# Altium schematic sheet RCU_v2_Comms.SchDoc(RCU_v2_Comms)
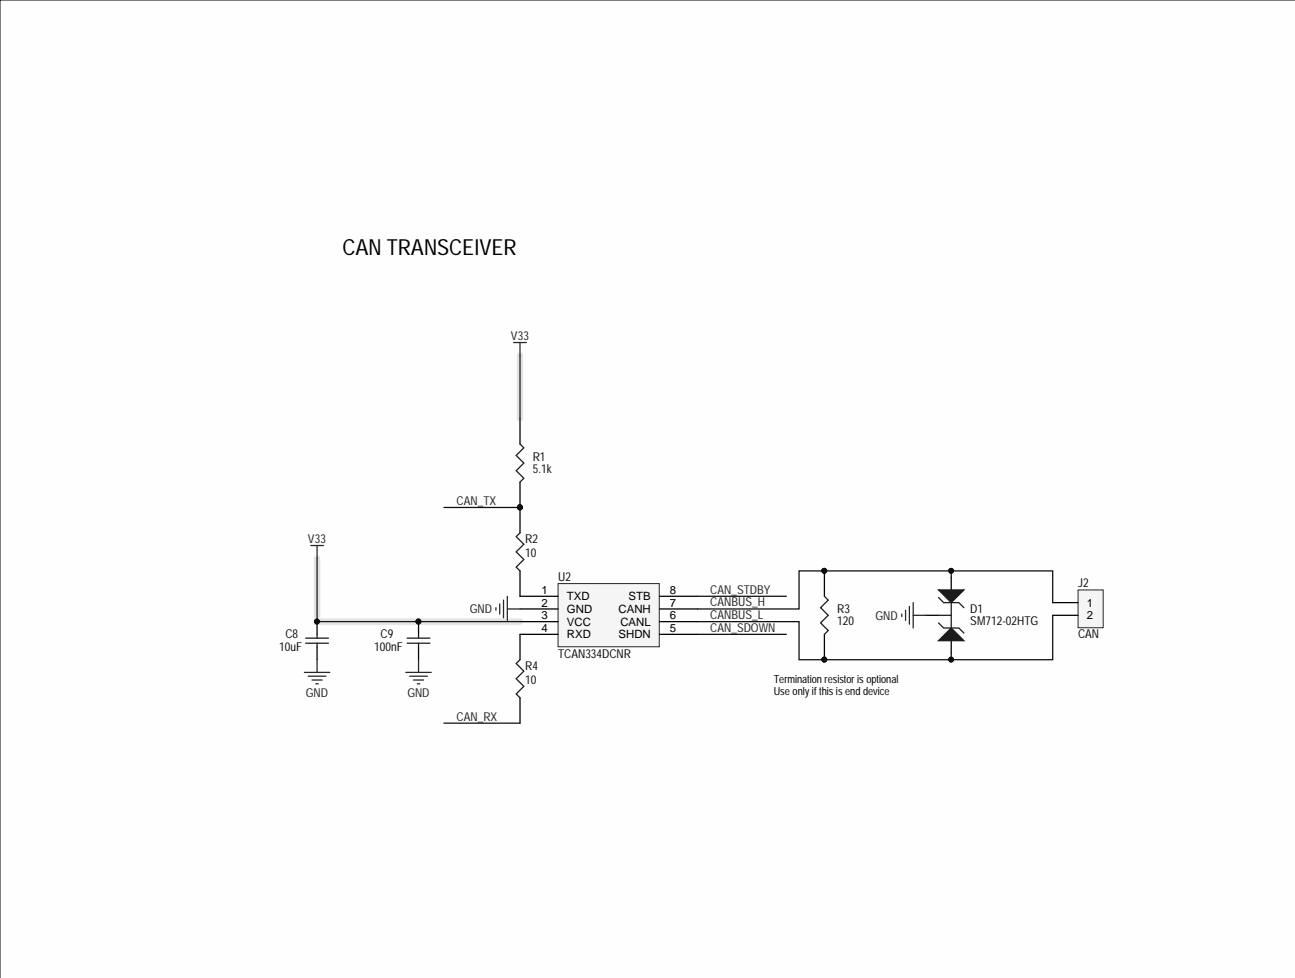
[diagram p0: RCU_v2_Comms.SchDoc, top left]
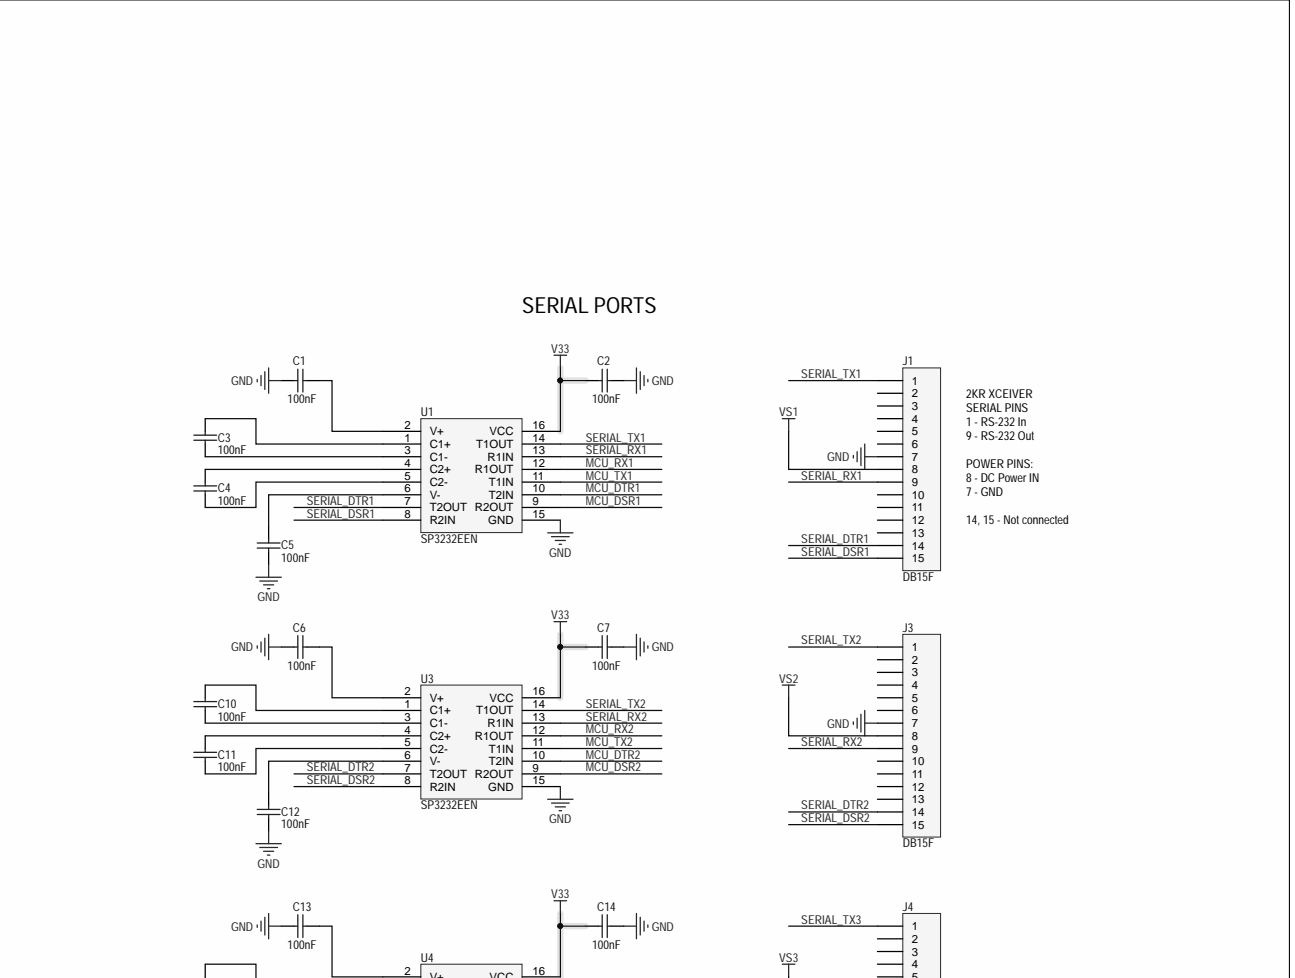
[diagram p1: RCU_v2_Comms.SchDoc, top right]
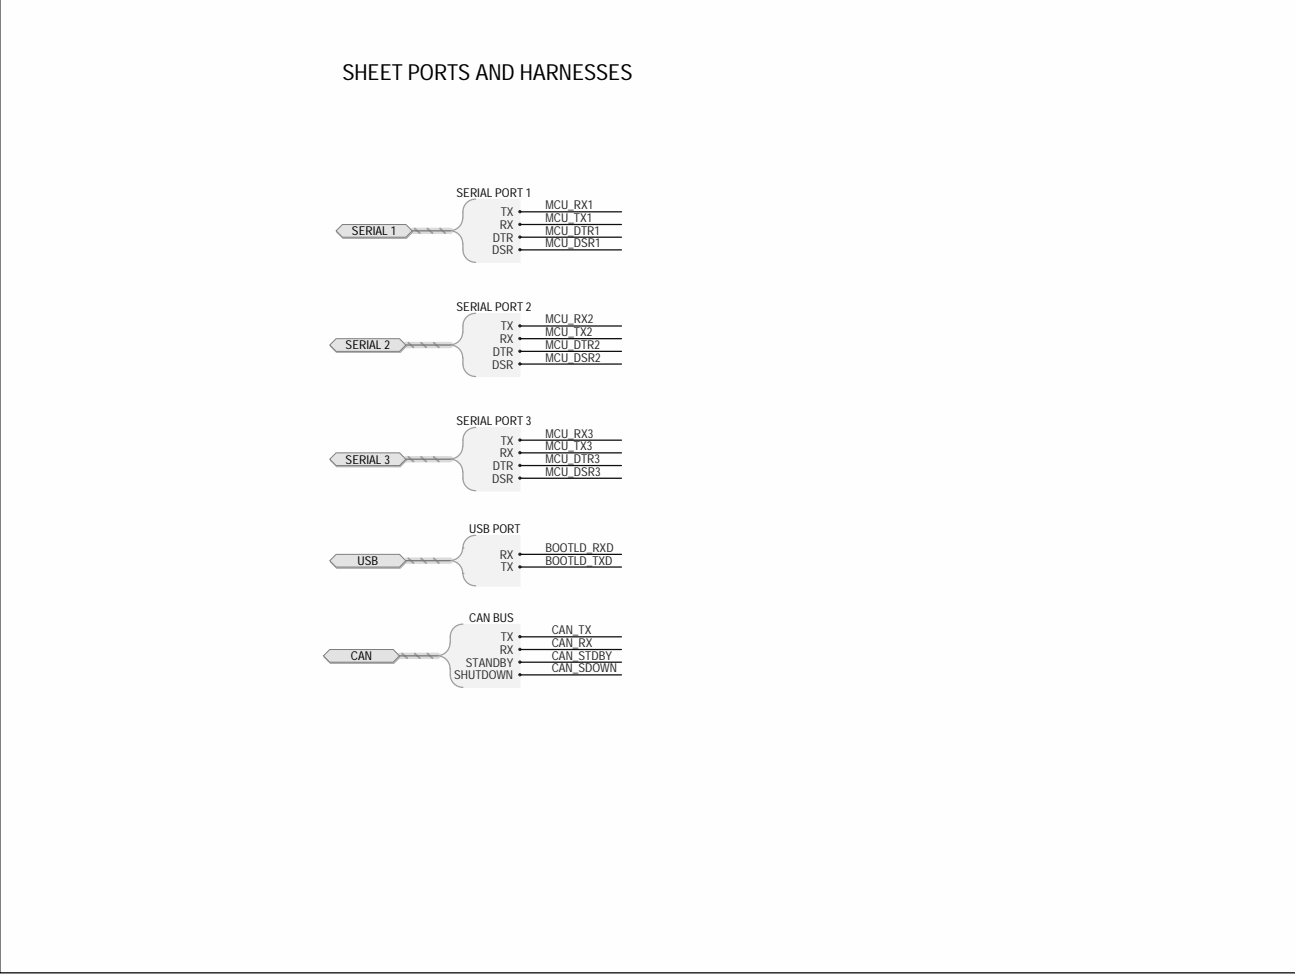
[diagram p2: RCU_v2_Comms.SchDoc, bottom left]
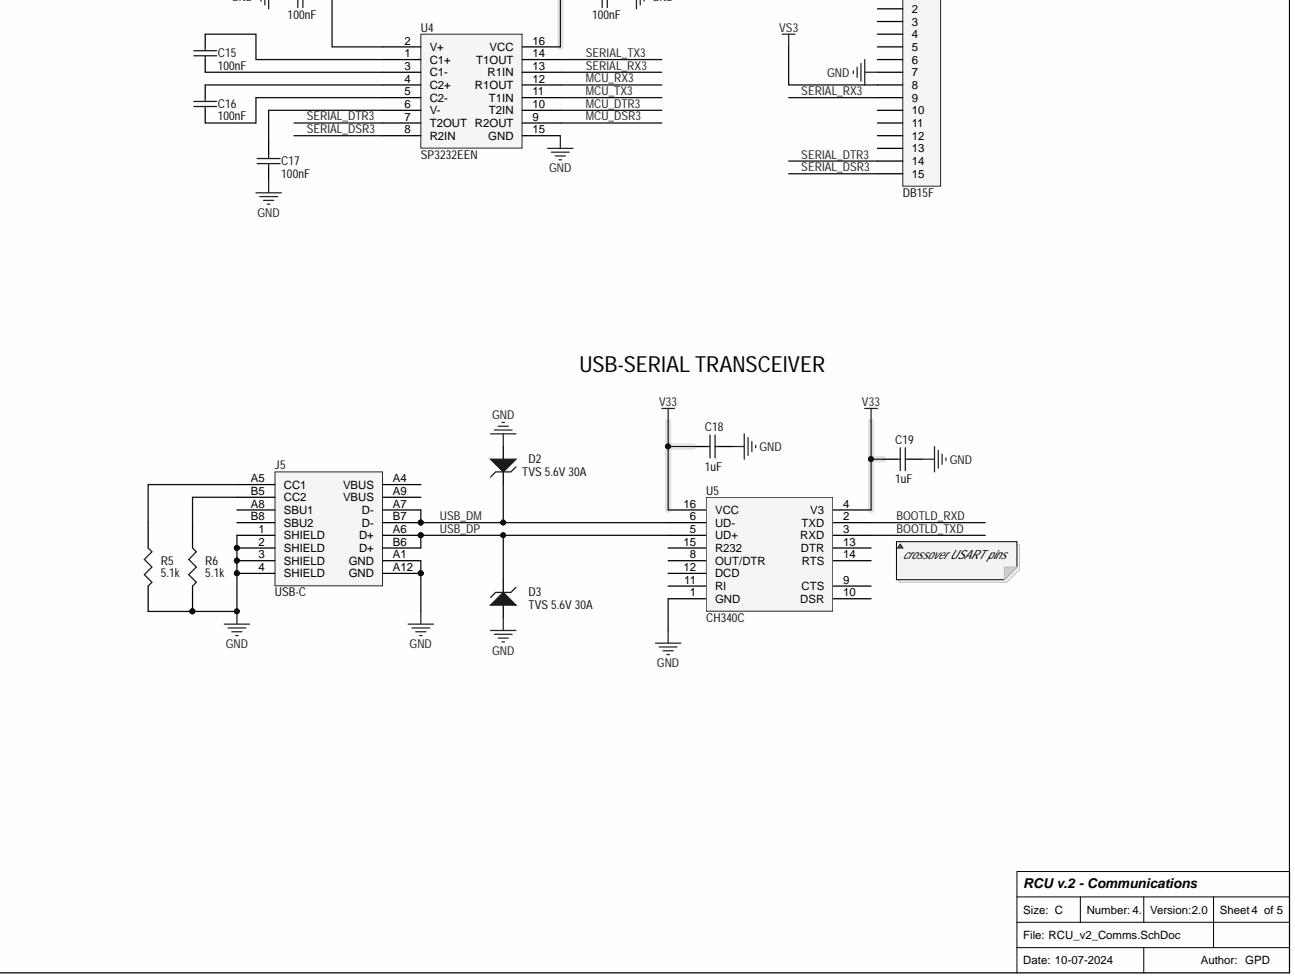
[diagram p3: RCU_v2_Comms.SchDoc, bottom right]

## Parts
C1  100nF  [C0402]
C2  100nF  [C0402]
C3  100nF  [C0402]
C4  100nF  [C0402]
C5  100nF  [C0402]
C6  100nF  [C0402]
C7  100nF  [C0402]
C8  10uF  [C1206]
C9  100nF  [C0402]
C10  100nF  [C0402]
C11  100nF  [C0402]
C12  100nF  [C0402]
C13  100nF  [C0402]
C14  100nF  [C0402]
C15  100nF  [C0402]
C16  100nF  [C0402]
C17  100nF  [C0402]
C18  1uF  [C0402]
C19  1uF  [C0402]
D1  SM712-02HTG  [SOT23_MBR4148]
D2  TVS 5.6V 30A  [SOD523]
D3  TVS 5.6V 30A  [SOD523]
J1  DB15F  [DB15FLE]
J2  CAN  [SIP2]
J3  DB15F  [DB15FLE]
J4  DB15F  [DB15FLE]
J5  USB-C  [USB_C_2]
R1  5.1k  [R0402]
R2  10  [R0402]
R3  120  [R1206]
R4  10  [R0402]
R5  5.1k  [R0402]
R6  5.1k  [R0402]
U1  SP3232EEN  [SO-G16]
U2  TCAN334DCNR  [SO-G8]
U3  SP3232EEN  [SO-G16]
U4  SP3232EEN  [SO-G16]
U5  CH340C  [SO-G16]

## Ports
CAN, CAN.RX, CAN.SHUTDOWN, CAN.STANDBY, CAN.TX, SERIAL 1, SERIAL 1.DSR, SERIAL 1.DTR, SERIAL 1.RX, SERIAL 1.TX, SERIAL 2, SERIAL 2.DSR, SERIAL 2.DTR, SERIAL 2.RX, SERIAL 2.TX, SERIAL 3, SERIAL 3.DSR, SERIAL 3.DTR, SERIAL 3.RX, SERIAL 3.TX, USB, USB.RX, USB.TX

## Nets
GND: C1.2 C2.1 C5.2 C6.2 C7.1 C8.2 C9.2 C12.2 C13.2 C14.1 C17.2 C18.2 C19.2 D1.2 D2.1 D3.1 J1.7 J3.7 J4.7 J5.1(SHIELD) J5.2(SHIELD) J5.3(SHIELD) J5.4(SHIELD) J5.A1(GND) J5.A12(GND) R5.2 R6.2 U1.15(GND) U2.2(GND) U3.15(GND) U4.15(GND) U5.1(GND)
V33: C2.2 C7.2 C8.1 C9.1 C14.2 C18.1 C19.1 R1.1 U1.16(VCC) U2.3(VCC) U3.16(VCC) U4.16(VCC) U5.4(V3) U5.16(VCC)
VS1: J1.8
VS2: J3.8
VS3: J4.8
BOOTLD_RXD: U5.2(TXD) ; port USB
BOOTLD_TXD: U5.3(RXD) ; port USB
CAN_RX: R4.1 ; port CAN
CAN_SDOWN: U2.5(SHDN) ; port CAN
CAN_STDBY: U2.8(STB) ; port CAN
CAN_TX: R1.2 R2.2 ; port CAN
CANBUS_H: D1.3 J2.1 R3.1 U2.7(CANH)
CANBUS_L: D1.1 J2.2 R3.2 U2.6(CANL)
MCU_DSR1: U1.9(R2OUT) ; port SERIAL 1
MCU_DSR2: U3.9(R2OUT) ; port SERIAL 2
MCU_DSR3: U4.9(R2OUT) ; port SERIAL 3
MCU_DTR1: U1.10(T2IN) ; port SERIAL 1
MCU_DTR2: U3.10(T2IN) ; port SERIAL 2
MCU_DTR3: U4.10(T2IN) ; port SERIAL 3
MCU_RX1: U1.12(R1OUT) ; port SERIAL 1
MCU_RX2: U3.12(R1OUT) ; port SERIAL 2
MCU_RX3: U4.12(R1OUT) ; port SERIAL 3
MCU_TX1: U1.11(T1IN) ; port SERIAL 1
MCU_TX2: U3.11(T1IN) ; port SERIAL 2
MCU_TX3: U4.11(T1IN) ; port SERIAL 3
SERIAL_DSR1: J1.15 U1.8(R2IN)
SERIAL_DSR2: J3.15 U3.8(R2IN)
SERIAL_DSR3: J4.15 U4.8(R2IN)
SERIAL_DTR1: J1.14 U1.7(T2OUT)
SERIAL_DTR2: J3.14 U3.7(T2OUT)
SERIAL_DTR3: J4.14 U4.7(T2OUT)
SERIAL_RX1: J1.9 U1.13(R1IN)
SERIAL_RX2: J3.9 U3.13(R1IN)
SERIAL_RX3: J4.9 U4.13(R1IN)
SERIAL_TX1: J1.1 U1.14(T1OUT)
SERIAL_TX2: J3.1 U3.14(T1OUT)
SERIAL_TX3: J4.1 U4.14(T1OUT)
USB_DM: D2.2 J5.A7(D-) J5.B7(D-) U5.6(UD-)
USB_DP: D3.2 J5.A6(D+) J5.B6(D+) U5.5(UD+)
NetC1_1: C1.1 U1.2(V+)
NetC3_1: C3.1 U1.1(C1+)
NetC3_2: C3.2 U1.3(C1-)
NetC4_1: C4.1 U1.4(C2+)
NetC4_2: C4.2 U1.5(C2-)
NetC5_1: C5.1 U1.6(V-)
NetC6_1: C6.1 U3.2(V+)
NetC10_1: C10.1 U3.1(C1+)
NetC10_2: C10.2 U3.3(C1-)
NetC11_1: C11.1 U3.4(C2+)
NetC11_2: C11.2 U3.5(C2-)
NetC12_1: C12.1 U3.6(V-)
NetC13_1: C13.1 U4.2(V+)
NetC15_1: C15.1 U4.1(C1+)
NetC15_2: C15.2 U4.3(C1-)
NetC16_1: C16.1 U4.4(C2+)
NetC16_2: C16.2 U4.5(C2-)
NetC17_1: C17.1 U4.6(V-)
NetJ5_A5: J5.A5(CC1) R5.1
NetJ5_B5: J5.B5(CC2) R6.1
NetR2_1: R2.1 U2.1(TXD)
NetR4_2: R4.2 U2.4(RXD)

Not connected: J1 2 3 4 5 6 10 11 12 13; J3 2 3 4 5 6 10 11 12 13; J4 2 3 4 5 6 10 11 12 13; J5 A4(VBUS) A8(SBU1) A9(VBUS) B8(SBU2); U5 8(OUT/DTR) 9(CTS) 10(DSR) 11(RI) 12(DCD) 13(DTR) 14(RTS) 15(R232)

## Library data of the parts
C1-C7 C9-C17 = CAP: 100nF ±10% 16V X7R 0402 Multilayer Ceramic Capacitors MLCC - SMD/SMT RoHS; Manufacturer Part Number: C0402C104K4RAC-TU; Manufacturer: KEMET; Value: 100nF; Supplier Part Number 3: 399-C0402C104K4RAC7867DKR-ND; Supplier Part Number 2: 8015145; Supplier Part Number 1: 80-C0402C104K4R; Supplier 3: Digikey; Supplier 2: Rs; Supplier 1: Mouser; Library Name: Miscellaneous Devices.SchLib; Component Kind: Standard
C8 = CAP: Multilayer Ceramic Capacitor, 10 uF, 50 V, ± 20%, X5R, 1206 [3216 Metric]; Manufacturer Part Number: UMK316BBJ106ML-T; Manufacturer: Taiyo Yuden; Value: 10uF; Supplier Part Number 3: C97712; Supplier Part Number 2: 963-UMK316BBJ106ML-T; Supplier Part Number 1: 587-3248-6-ND; Supplier 3: LCSC; Supplier 2: Mouser; Supplier 1: Digikey; Library Name: Miscellaneous Devices.SchLib; Component Kind: Standard
C18 C19 = CAP: Multilayer Ceramic Capacitor, 1 uF, 10 V, ± 10%, X5R, 0402 [1005 Metric]; Manufacturer Part Number: CC0402KRX5R6BB105; Manufacturer: Yageo; Value: 1uF; Supplier Part Number 3: 603-CC402KRX5R6BB105; Supplier Part Number 2: 311-1439-6-ND; Supplier Part Number 1: 311-1439-1-ND; Supplier 3: Mouser; Supplier 2: Digikey; Supplier 1: Digikey; Library Name: Miscellaneous Devices.SchLib; Component Kind: Standard
D1 = DZ89C180: SM712 Series 31 V 600 W Surface Mount Asymmetrical TVS Diode Array - SOT-23-3; Manufacturer: Littelfuse; Value: SM712-02HTG; Supplier Part Number 3: C172881; Supplier Part Number 2: F6450DKR-ND; Supplier Part Number 1: 576-SM712-02HTG; Supplier 3: LCSC; Supplier 2: Digikey; Supplier 1: Mouser; Simulation: DZ89C180; Manufacturer Part Number: SM712-02HTG; Library Name: DIODE.SchLib; Component Kind: Standard
D2 D3 = 1N5380B: Epcos B72500D0050A060; Bi-Directional TVS Diode; 1000W; 2-Pin SOD-523; Manufacturer: TDK EPCOS; Value: TVS 5.6V 30A; Supplier Part Number 3: 4962968P; Supplier Part Number 2: 495-3414-6-ND; Supplier Part Number 1: C210787; Supplier 3: Rs; Supplier 2: Digikey; Supplier 1: LCSC; Simulation: 1N5380B; Manufacturer Part Number: B72500D0050A060; Library Name: DIODE.SchLib; Component Kind: Standard
J1 J3 J4 = CON15: D Subminiature Connector, 15 Contact(S), Female, 0.108 Inch Pitch, Solder Terminal, M3, Socket; Manufacturer Part Number: D15S13A4GL00LF; Manufacturer: Amphenol Communications Solutions; Value: DB15F; Supplier Part Number 3: 649-D15S13A4GL00LF; Supplier Part Number 2: 252377; Supplier Part Number 1: 609-1494-ND; Supplier 3: Mouser; Supplier 2: Rs; Supplier 1: Digikey; Library Name: Miscellaneous Devices.SchLib; Component Kind: Standard
J2 = CON2: Conn Unshrouded Header HDR 2 POS Solder ST Top Entry Thru-Hole; Manufacturer Part Number: 69157-102HLF; Manufacturer: Amphenol ICC / FCI; Value: CAN; Supplier Part Number 3: 649-69157-102HLF; Supplier Part Number 2: 3272976; Supplier Part Number 1: 609-5537-ND; Supplier 3: Mouser; Supplier 2: Farnell; Supplier 1: Digikey; Library Name: Miscellaneous Devices.SchLib; Component Kind: Standard
J5 = USB-C-CONN2: USB 2.0 5A 1 Surface Mount，Right Angle 16P Female -40℃~+85℃ Gold High Conductivity Copper Type-C SMD USB Connectors ROHS; Manufacturer Part Number: GT-USB-7047B; Manufacturer: G-Switch; Value: USB-C; Supplier Part Number 3: C963217; Supplier Part Number 2: C963217; Supplier Part Number 1: C963217; Supplier 3: LCSC; Supplier 2: LCSC; Supplier 1: LCSC; Component Kind: Standard; Library Name: Varios.SchLib
R1 R5 R6 = RES1: SMD Chip Resistor, 5.1 kOhm, ± 5%, 63 mW, 0402 [1005 Metric], Thick Film, General Purpose; Manufacturer Part Number: RC0402JR-075K1L; Manufacturer: Yageo; Value: 5.1k; Supplier Part Number 3: C105873; Supplier Part Number 2: 311-5.1KJRCT-ND; Supplier Part Number 1: 603-RC0402JR-075K1L; Supplier 3: LCSC; Supplier 2: Digikey; Supplier 1: Mouser; Library Name: Miscellaneous Devices.SchLib; Component Kind: Standard
R2 R4 = RES1: SMD Chip Resistor, 10 Ohm, ± 1%, 63 mW, 0402 [1005 Metric], Thick Film, General Purpose; Manufacturer Part Number: CR0402-FX-10R0GLF; Manufacturer: Bourns; Value: 10; Supplier Part Number 3: CR0402-FX-10R0GLFDKR-ND; Supplier Part Number 2: 652-CR0402FX-10R0GLF; Supplier Part Number 1: 4015738; Supplier 3: Digikey; Supplier 2: Mouser; Supplier 1: Farnell; Library Name: Miscellaneous Devices.SchLib; Component Kind: Standard
R3 = RES1: SMD Chip Resistor, 120 Ohm, ± 1%, 250 mW, 1206 [3216 Metric], Thick Film, General Purpose; Manufacturer Part Number: CR1206-FX-1200ELF; Manufacturer: Bourns; Value: 120; Supplier Part Number 3: 2333562; Supplier Part Number 2: 652-CR1206FX-1200ELF; Supplier Part Number 1: CR1206-FX-1200ELFDKR-ND; Supplier 3: Farnell; Supplier 2: Mouser; Supplier 1: Digikey; Library Name: Miscellaneous Devices.SchLib; Component Kind: Standard
U1 U3 U4 = MAX3232: SOP-16 RS232 ICs ROHS; Manufacturer: UMW; Value: SP3232EEN; Supplier Part Number 3: 4518-SP3232EENCT-ND; Supplier Part Number 2: 4518-SP3232EENDKR-ND; Supplier Part Number 1: C2904739; Supplier 3: Digikey; Supplier 2: Digikey; Supplier 1: LCSC; Manufacturer Part Number: SP3232EEN; Library Name: ICs.SchLib; ComponentLink1URL: https://www.lcsc.com/datasheet/lcsc_datasheet_2402231528_UMW-Youtai-Semiconductor-Co---Ltd--SP3232EEN_C2904739.pdf; ComponentLink1Description: Datasheet; Component Kind: Standard
U2 = PIC12F629/675: CAN 1Mbps Normal/Standby/Shutdown 3.6V 8-Pin SOT-23 T/R; Manufacturer: Texas Instruments; Value: TCAN334DCNR; Supplier Part Number 3: 296-47830-1-ND; Supplier Part Number 2: 595-TCAN334DCNR; Supplier Part Number 1: TCAN334DCNR; Supplier 3: Digikey; Supplier 2: Mouser; Supplier 1: Texas Instruments; Manufacturer Part Number: TCAN334DCNR; Library Name: PICs.SchLib; ComponentLink2URL: http://www.ti.com/lit/ds/symlink/tcan330.pdf; ComponentLink2Description: Datasheet; Component Kind: Standard
U5 = CH340C: Transceiver 2Mbps USB 2.0 SOP-16_150mil USB Ics ROHS; Manufacturer: WCH; Value: CH340C; Supplier Part Number 3: C84681; Supplier Part Number 2: C84681; Supplier Part Number 1: C7464026; Supplier 3: LCSC; Supplier 2: LCSC; Supplier 1: LCSC; Manufacturer Part Number: CH340C; Library Name: ICs.SchLib; ComponentLink1URL: https://cdn.sparkfun.com/datasheets/Dev/Arduino/Other/CH340DS1.PDF; ComponentLink1Description: Datasheet; Component Kind: Standard
